# Altium schematic sheet 02-Power.SchDoc
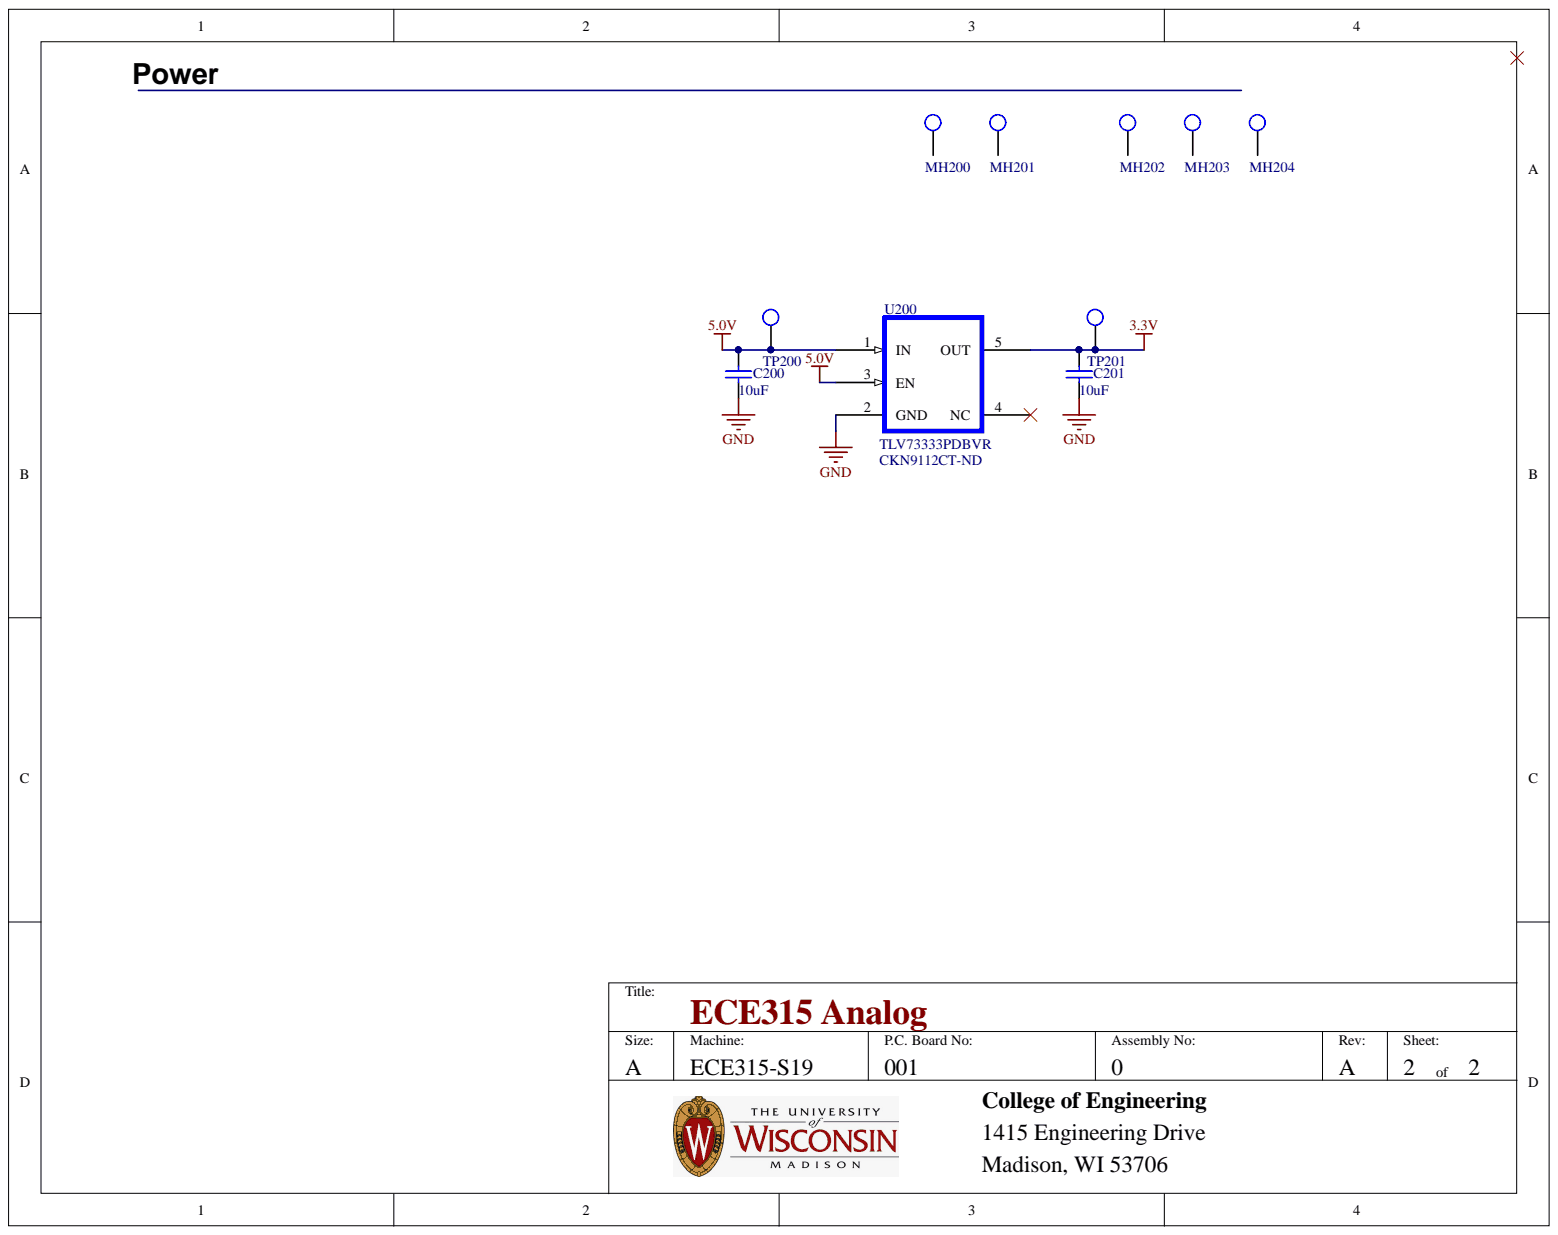
[diagram p0: 02-Power.SchDoc]

## Parts
C200  10uF  [CAP_0805]
C201  10uF  [CAP_0805]
MH200  MHOLE_2_55MM  [MHOLE_2_55MM]  (value not drawn)
MH201  MHOLE_2_55MM  [MHOLE_2_55MM]  (value not drawn)
MH202  MHOLE_2_55MM  [MHOLE_2_55MM]  (value not drawn)
MH203  MHOLE_2_55MM  [MHOLE_2_55MM]  (value not drawn)
MH204  MHOLE_2_55MM  [MHOLE_2_55MM]  (value not drawn)
TP200  TP_1206  [TP-SMC-1206]  (value not drawn)
TP201  TP_1206  [TP-SMC-1206]  (value not drawn)
U200  TLV73333PDBVR  Supplier Part Number 1: CKN9112CT-ND  [IC_TLV73333PDBVR]

## Nets
3.3V: C201.1 TP201.1 U200.5(OUT)
5.0V: C200.1 TP200.1 U200.1(IN) U200.3(EN)
GND: C200.2 C201.2 U200.2(GND)
N00004:

Not connected: MH200 1; MH201 1; MH202 1; MH203 1; MH204 1; U200 4(NC)

## Library data of the parts
C200 C201 = CAP_0805_U_010.00: CAP CER 10UF 16V X5R 0805; Manufacturer: Samsung; Manufacturer Part Number: CL21A106KOFNNNE; Tolerance: 10%; Capacitance: 10uF; Case/Package: 0805; Case Code (Imperial): 0805; Case Code (Metric): 2012; Component Kind: Standard; ComponentLink1Description: https://datasheet.ciiva.com/25730/cl21a106kpclqnc-25730594.pdf; ComponentLink1URL: https://datasheet.ciiva.com/25730/cl21a106kpclqnc-25730594.pdf; ComponentLink2Description: http://www.samsungsem.com/kr/support/product-search/mlcc/CL21A106KOFNNNE.jsp; ComponentLink2URL: http://www.samsungsem.com/kr/support/product-search/mlcc/CL21A106KOFNNNE.jsp; ComponentLink3Description: https://datasheet.ciiva.com/26920/getdatasheetpartid-355170-26920201.pdf; ComponentLink3URL: https://datasheet.ciiva.com/26920/getdatasheetpartid-355170-26920201.pdf; ComponentLink4Description: http://www1.futureelectronics.com/doc/SAMSUNG/CL21A106KOFNNNE.pdf; ComponentLink4URL: http://www1.futureelectronics.com/doc/SAMSUNG/CL21A106KOFNNNE.pdf; ComponentLink5Description: https://www.verical.com/server-webapp/html/getDatasheet?partID=354009; ComponentLink5URL: https://www.verical.com/server-webapp/html/getDatasheet?partID=354009; Depth: 1.25mm; Dielectric: X5R; Height: 1.25mm; Length: 2mm; Library Name: ece315.SCHLIB; Max Operating Temperature: 85°C; Min Operating Temperature: -55°C; Mount: Surface Mount; Package Quantity: 2000; Packaging: Tape and Reel; Pricing 1: 1=0.29, 10=0.199, 100=0.1009, 500=0.06904, 1000=0.06107 (USD); Radiation Hardening: No; Stock 1: 1158169; Supplier 1: Digi-Key; Thickness: 0.053inch; Voltage Rating: 16V; Width: 0.049inch
MH200-MH204 = MHOLE_2_55MM: Mounting Holde; Manufacturer Part Number: UW MTHOLE; Component Kind: Standard; Library Name: ece315-2018-fall.SchLib
TP200 TP201 = TP_1206: Test Point SMD 1206; Manufacturer: TE Connectivity (Tyco Electronics); Manufacturer Part Number: 1625854-1; Power Rating: N/R; Resistance Value: 0 Ohm; Case Size: 1206; Component Kind: Standard; ComponentLink1Description: https://datasheet.ciiva.com/6439/eng-ds-1773266-a-6439169.pdf; ComponentLink1URL: https://datasheet.ciiva.com/6439/eng-ds-1773266-a-6439169.pdf; ComponentLink2Description: https://datasheet.ciiva.com/30136/1773266-a-30136341.pdf; ComponentLink2URL: https://datasheet.ciiva.com/30136/1773266-a-30136341.pdf; ComponentLink3Description: https://datasheet.ciiva.com/6439/eng-ds-1773266-a-6439080.pdf; ComponentLink3URL: https://datasheet.ciiva.com/6439/eng-ds-1773266-a-6439080.pdf; ComponentLink4Description: https://datasheet.ciiva.com/6439/eng-ds-1773266-a-6439081.pdf; ComponentLink4URL: https://datasheet.ciiva.com/6439/eng-ds-1773266-a-6439081.pdf; ComponentLink5Description: https://datasheet.ciiva.com/6439/eng-ds-1773266-a-6439083.pdf; ComponentLink5URL: https://datasheet.ciiva.com/6439/eng-ds-1773266-a-6439083.pdf; Dimension: 3.2 x 1.6 x 1.25mm; Family: RC; Library Name: ece315.SCHLIB; Mounting: Surface Mount; Operating Temperature: -55 to 125 C; Packaging: Tape and Reel; Part No: 1625854-1; Pricing 1: 1=0.28, 10=0.261, 25=0.224, 50=0.1902, 100=0.1828, 250=0.1641, 500=0.1567, 1000=0.1306 (USD); RoHS: Yes; Stock 1: 31937; Supplier 1: Digi-Key; Supplier Part Number 1: A106143CT-ND; Temperature Coefficient: N/R; Terminal Pitch: N/R; Termination Style: N/R; Tolerance: N/R
U200 = TLV73333PDBVR: Manufacturer: Texas Instruments; Accuracy: 1%; Actuator Length: 0.85mm; Actuator Material: Nylon,Polyamide; Actuator Type: Standard; Contact Resistance: 100mR; Current Rating: 50mA; Dropout Voltage: 125mV; Illumination Type: Illuminated,Non-Illuminated; Max Input Voltage: 5.5V; Max Output Current: 300mA; Max Voltage Rating (DC): 12V; Mechanical Life: 100000Cycles; Min Current Limit: 360mA; Min Input Voltage: 1.4V; Number of Outputs: 1; Operating Force: 160gf; Output Type: Fixed; Output Voltage: 3.3V; Polarity: Positive; Quiescent Current: 60uA; Switch Function: Off-Mom; Throw Configuration: SPST; Case/Package: SOT-23-5; Color: Black; Component Kind: Standard; ComponentLink1Description: http://www.ti.com/general/docs/lit/getliterature.tsp?genericPartNumber=TLV733P&&fileType=pdf; ComponentLink1URL: http://www.ti.com/general/docs/lit/getliterature.tsp?genericPartNumber=TLV733P&&fileType=pdf; ComponentLink2Description: https://www.verical.com/server-webapp/html/getDatasheet?partID=786341; ComponentLink2URL: https://www.verical.com/server-webapp/html/getDatasheet?partID=786341; ComponentLink3Description: https://www.ckswitches.com/media/1471/pts645.pdf; ComponentLink3URL: https://www.ckswitches.com/media/1471/pts645.pdf; ComponentLink4Description: https://www.verical.com/server-webapp/html/getDatasheet?partID=764615; ComponentLink4URL: https://www.verical.com/server-webapp/html/getDatasheet?partID=764615; Contact Material: Silver; Contact Plating: Gold; Depth: 9mm; Lead Free: Lead Free; Length: 6mm; Library Name: ece315.SCHLIB; Manufacturer Part Number: TLV73333PDBVR; Max Current Rating: 50mA; Max Operating Temperature: 125°C; Min Operating Temperature: -40°C; Mount: Surface Mount; Number of Pins: 5; Number of Regulators: 1; Orientation: Straight; Output Current: 300mA; Package Quantity: 3000; Packaging: Tape and Reel; Pricing 1: 1=0.16, 10=0.157, 25=0.1516, 50=0.1462, 100=0.1381, 250=0.12996, 500=0.12452 (USD); Radiation Hardening: No; REACH SVHC: No SVHC; Stock 1: 783192; Supplier 1: Digi-Key; Termination: Gull Wing; Voltage Rating (DC): 12V; Weight: 0.000557oz
